# Altium schematic sheet PFM_microcontroller.SchDoc(PFM_microcontroller)
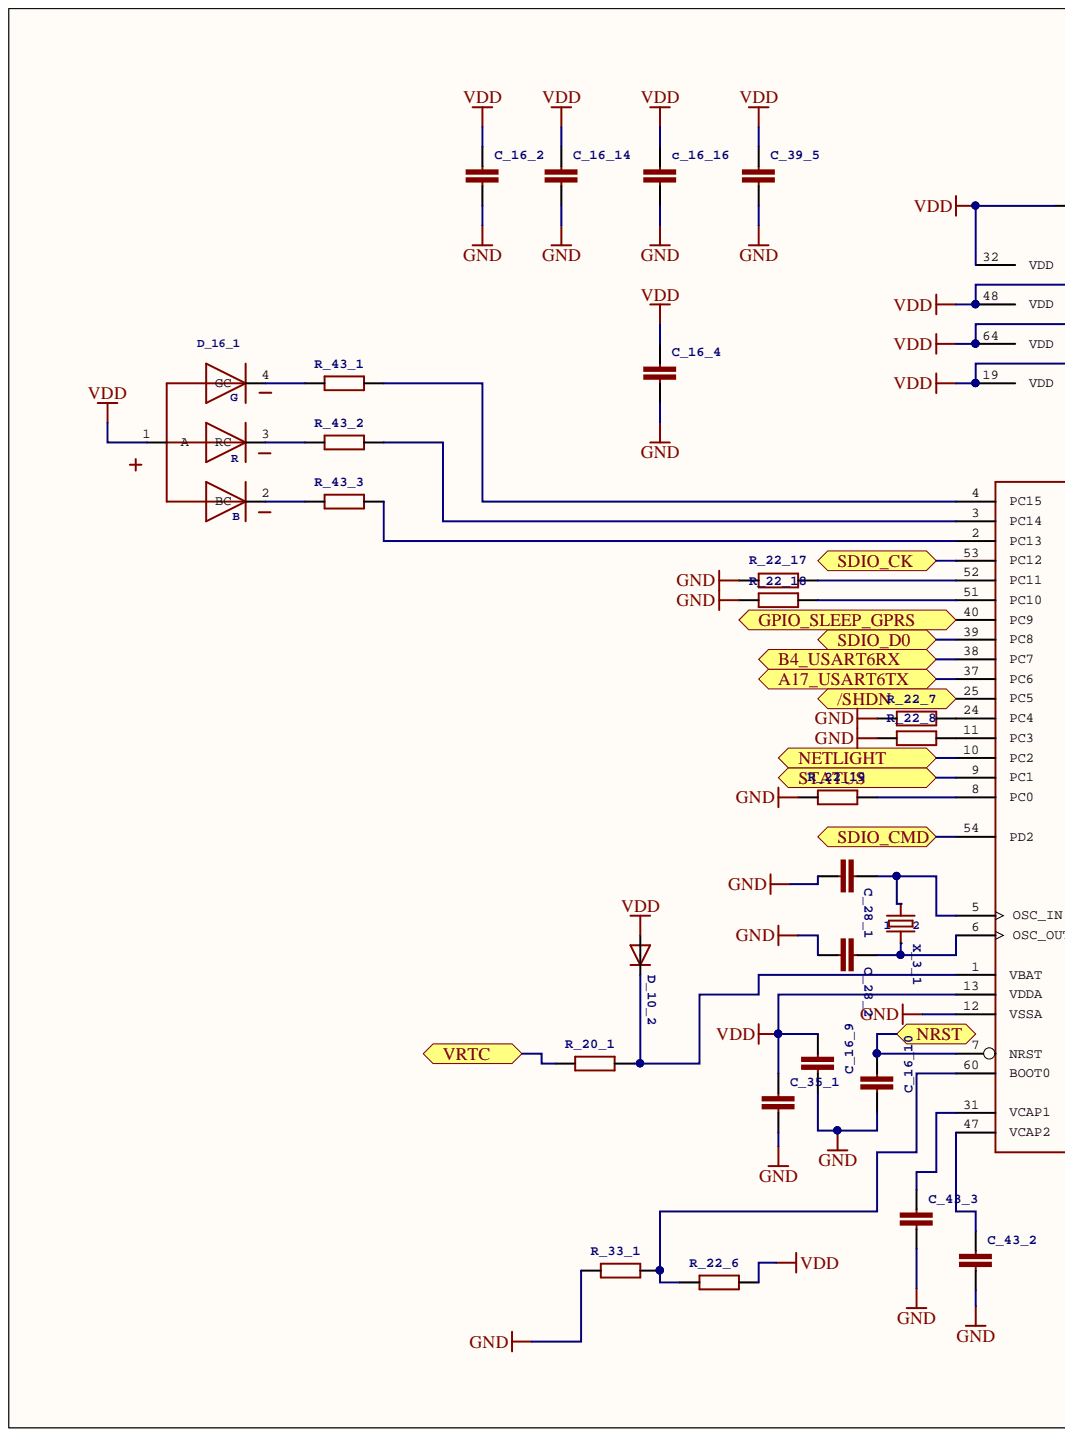
[diagram p0: PFM_microcontroller.SchDoc, left]
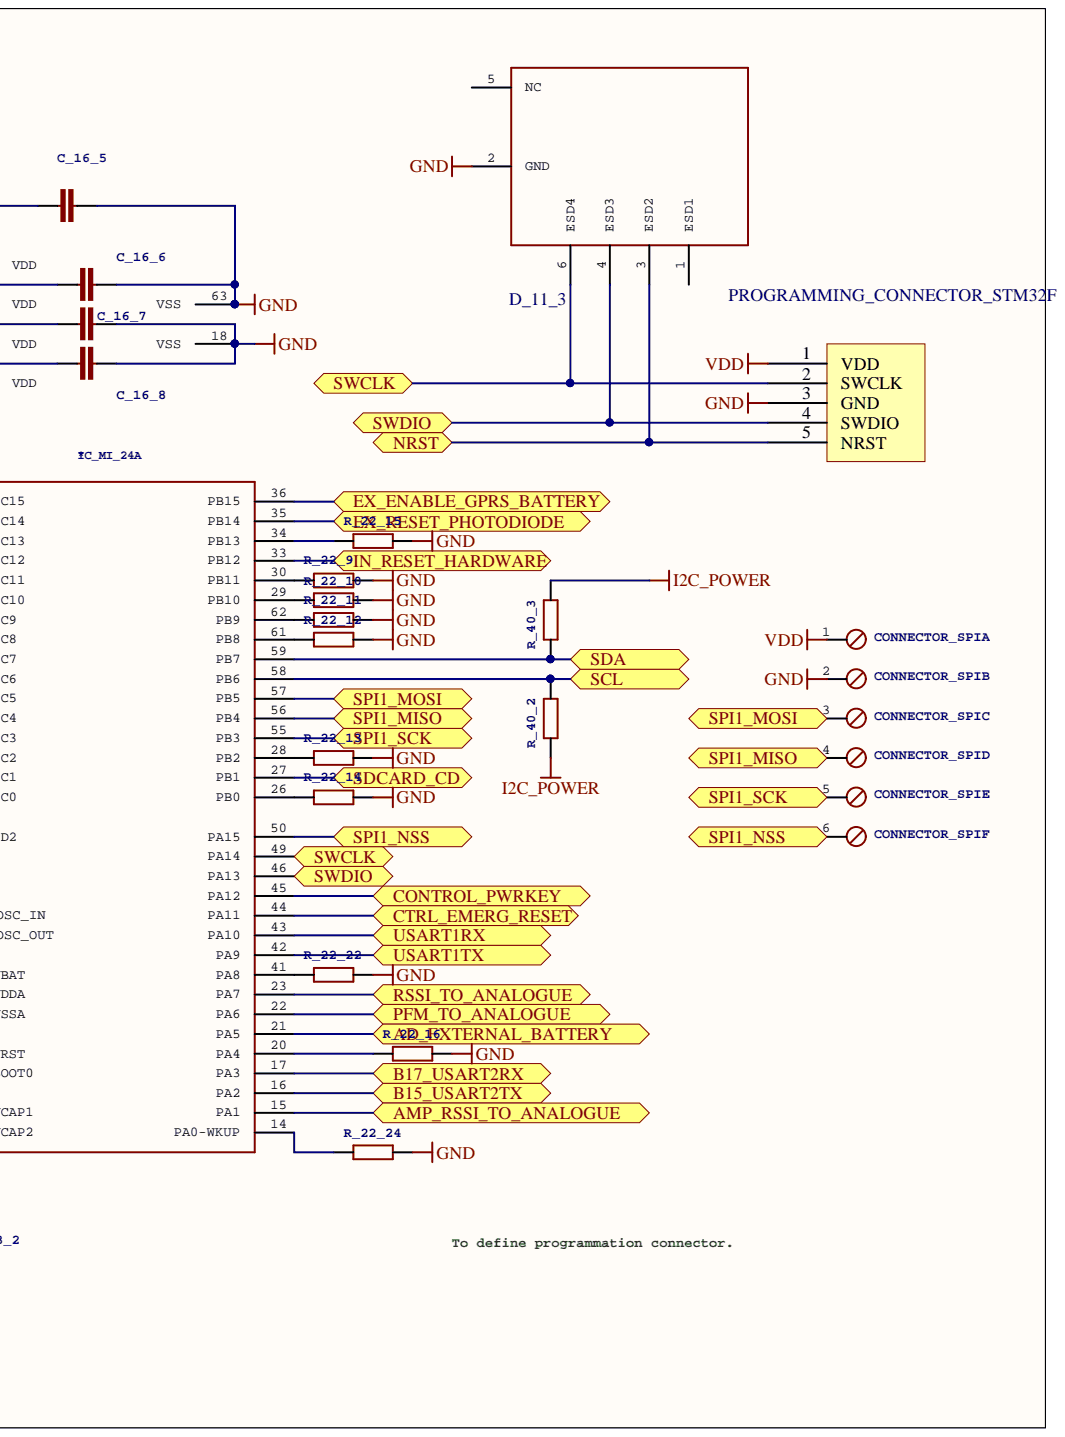
[diagram p1: PFM_microcontroller.SchDoc, right]

## Parts
C_16_2  100nF;CL10B104KB8NNNC;Samsung;  [C0603]  (value not drawn)
C_16_4  100nF;CL10B104KB8NNNC;Samsung;  [C0603]  (value not drawn)
C_16_5  100nF;CL10B104KB8NNNC;Samsung;  [C0603]  (value not drawn)
C_16_6  100nF;CL10B104KB8NNNC;Samsung;  [C0603]  (value not drawn)
C_16_7  100nF;CL10B104KB8NNNC;Samsung;  [C0603]  (value not drawn)
C_16_8  100nF;CL10B104KB8NNNC;Samsung;  [C0603]  (value not drawn)
C_16_9  100nF;CL10B104KB8NNNC;Samsung;  [C0603]  (value not drawn)
C_16_10  100nF;CL10B104KB8NNNC;Samsung;  [C0603]  (value not drawn)
C_16_14  100nF;CL10B104KB8NNNC;Samsung;  [C0603]  (value not drawn)
c_16_16  100nF;CL10B104KB8NNNC;Samsung;  [C0603]  (value not drawn)
C_28_1  10pF;C1608C0G1H100D;TDK  [C0603K]  (value not drawn)
C_28_2  10pF;C1608C0G1H100D;TDK  [C0603K]  (value not drawn)
C_35_1  1uF;MC0603F105Z100CT;Multicomp  [C0603K]  (value not drawn)
C_39_5  4.7uF;CL10A475KO8NNNC;Samsung  [C0603]  (value not drawn)
C_43_2  2.2uF;C0603C225K9PACTU;Kemet  [C0603K]  (value not drawn)
C_43_3  2.2uF;C0603C225K9PACTU;Kemet  [C0603K]  (value not drawn)
CONNECTOR_SPI  PHOENIX CONNECTOR  [6POL254]  (value not drawn)  (parts A,B,C,D,E,F)
D_10_2  SCHOTTKY;PMEG3010BER,115;NEXPERIA  [SOD123]  (value not drawn)
D_11_3  PUSB2X4YH;Nexperia;  [PUSB2X4Y_BRD]  (value not drawn)
D_16_1  RGBLED;150141M173100;WURTH ELECTRONICS  [3528-COMMON-ANODE]  (value not drawn)
IC_MI_24  IC_MI_24;STM32F215RET;STMicroelectronics;  [LQFP64]  (value not drawn)  (parts A,B)
PROGRAMMING_CONNECTOR_STM32F  SIL_PC_5  [SIL_PC_5]  (value not drawn)
R_20_1  0;ERJ3GEY0R00V;Panasonic;  [R0603]  (value not drawn)
R_22_6  10k;MCR03ERTJ103;Rohm  [R0603]  (value not drawn)
R_22_7  10k;MCR03ERTJ103;Rohm  [R0603]  (value not drawn)
R_22_8  10k;MCR03ERTJ103;Rohm  [R0603]  (value not drawn)
R_22_9  10k;MCR03ERTJ103;Rohm  [R0603]  (value not drawn)
R_22_10  10k;MCR03ERTJ103;Rohm  [R0603]  (value not drawn)
R_22_11  10k;MCR03ERTJ103;Rohm  [R0603]  (value not drawn)
R_22_12  10k;MCR03ERTJ103;Rohm  [R0603]  (value not drawn)
R_22_13  10k;MCR03ERTJ103;Rohm  [R0603]  (value not drawn)
R_22_14  10k;MCR03ERTJ103;Rohm  [R0603]  (value not drawn)
R_22_15  10k;MCR03ERTJ103;Rohm  [R0603]  (value not drawn)
R_22_16  10k;MCR03ERTJ103;Rohm  [R0603]  (value not drawn)
R_22_17  10k;MCR03ERTJ103;Rohm  [R0603]  (value not drawn)
R_22_18  10k;MCR03ERTJ103;Rohm  [R0603]  (value not drawn)
R_22_19  10k;MCR03ERTJ103;Rohm  [R0603]  (value not drawn)
R_22_22  10k;MCR03ERTJ103;Rohm  [R0603]  (value not drawn)
R_22_24  10k;MCR03ERTJ103;Rohm  [R0603]  (value not drawn)
R_33_1  470;ERJ-3GEYJ471V;Panasonic  [R0603]  (value not drawn)
R_40_2  2k;ERJ-3GEYJ202V;Panasonic  [R0603]  (value not drawn)
R_40_3  2k;ERJ-3GEYJ202V;Panasonic  [R0603]  (value not drawn)
R_43_1  180;RC1608J181CS;Samsung  [R0603]  (value not drawn)
R_43_2  180;RC1608J181CS;Samsung  [R0603]  (value not drawn)
R_43_3  180;RC1608J181CS;Samsung  [R0603]  (value not drawn)
X_3_1  26MHz;7B-26.000MEEQ-T;TXC  [CTS406]  (value not drawn)

## Ports
/SHDN, A17_USART6TX, AD_EXTERNAL_BATTERY, AMP_RSSI_TO_ANALOGUE, B4_USART6RX, B15_USART2TX, B17_USART2RX, CONTROL_PWRKEY, CTRL_EMERG_RESET, EX_ENABLE_GPRS_BATTERY, EX_RESET_PHOTODIODE, GPIO_SLEEP_GPRS, IN_RESET_HARDWARE, NETLIGHT, NRST, PFM_TO_ANALOGUE, RSSI_TO_ANALOGUE, SCL, SDA, SDCARD_CD, SDIO_CK, SDIO_CMD, SDIO_D0, SPI1_MISO, SPI1_MOSI, SPI1_NSS, SPI1_SCK, STATUS, SWCLK, SWDIO, USART1RX, USART1TX, VRTC

## Nets
GND: C_16_2.2 C_16_4.2 C_16_5.2 C_16_6.2 C_16_7.2 C_16_8.2 C_16_9.1 C_16_10.1 C_16_14.2 c_16_16.2 C_28_1.2 C_28_2.2 C_35_1.2 C_39_5.2 C_43_2.2 C_43_3.2 CONNECTOR_SPI.2 D_11_3.2(GND) IC_MI_24.12(VSSA) IC_MI_24.18(VSS) IC_MI_24.63(VSS) PROGRAMMING_CONNECTOR_STM32F.3(GND) R_22_7.1 R_22_8.1 R_22_9.2 R_22_10.2 R_22_11.2 R_22_12.2 R_22_13.2 R_22_14.2 R_22_15.2 R_22_16.2 R_22_17.1 R_22_18.1 R_22_19.1 R_22_22.2 R_22_24.2 R_33_1.1
I2C_POWER: R_40_2.1 R_40_3.2
VDD: C_16_2.1 C_16_4.1 C_16_5.1 C_16_6.1 C_16_7.1 C_16_8.1 C_16_9.2 C_16_14.1 c_16_16.1 C_35_1.1 C_39_5.1 CONNECTOR_SPI.1 D_10_2.A D_16_1.1(A) IC_MI_24.13(VDDA) IC_MI_24.19(VDD) IC_MI_24.32(VDD) IC_MI_24.48(VDD) IC_MI_24.64(VDD) PROGRAMMING_CONNECTOR_STM32F.1(VDD) R_22_6.2
/SHDN: IC_MI_24.25(PC5) ; port /SHDN
A17_USART6TX: IC_MI_24.37(PC6) ; port A17_USART6TX
AD_EXTERNAL_BATTERY: IC_MI_24.21(PA5) ; port AD_EXTERNAL_BATTERY
AMP_RSSI_TO_ANALOGUE: IC_MI_24.15(PA1) ; port AMP_RSSI_TO_ANALOGUE
B4_USART6RX: IC_MI_24.38(PC7) ; port B4_USART6RX
B15_USART2TX: IC_MI_24.16(PA2) ; port B15_USART2TX
B17_USART2RX: IC_MI_24.17(PA3) ; port B17_USART2RX
CONTROL_PWRKEY: IC_MI_24.45(PA12) ; port CONTROL_PWRKEY
CTRL_EMERG_RESET: IC_MI_24.44(PA11) ; port CTRL_EMERG_RESET
EX_ENABLE_GPRS_BATTERY: IC_MI_24.36(PB15) ; port EX_ENABLE_GPRS_BATTERY
EX_RESET_PHOTODIODE: IC_MI_24.35(PB14) ; port EX_RESET_PHOTODIODE
GPIO_SLEEP_GPRS: IC_MI_24.40(PC9) ; port GPIO_SLEEP_GPRS
IN_RESET_HARDWARE: IC_MI_24.33(PB12) ; port IN_RESET_HARDWARE
NETLIGHT: IC_MI_24.10(PC2) ; port NETLIGHT
NRST: C_16_10.2 D_11_3.3(ESD2) IC_MI_24.7(NRST) PROGRAMMING_CONNECTOR_STM32F.5(NRST) ; port NRST
PFM_TO_ANALOGUE: IC_MI_24.22(PA6) ; port PFM_TO_ANALOGUE
RSSI_TO_ANALOGUE: IC_MI_24.23(PA7) ; port RSSI_TO_ANALOGUE
SCL: IC_MI_24.58(PB6) R_40_2.2 ; port SCL
SDA: IC_MI_24.59(PB7) R_40_3.1 ; port SDA
SDCARD_CD: IC_MI_24.27(PB1) ; port SDCARD_CD
SDIO_CK: IC_MI_24.53(PC12) ; port SDIO_CK
SDIO_CMD: IC_MI_24.54(PD2) ; port SDIO_CMD
SDIO_D0: IC_MI_24.39(PC8) ; port SDIO_D0
SPI1_MISO: CONNECTOR_SPI.4 IC_MI_24.56(PB4) ; port SPI1_MISO
SPI1_MOSI: CONNECTOR_SPI.3 IC_MI_24.57(PB5) ; port SPI1_MOSI
SPI1_NSS: CONNECTOR_SPI.6 IC_MI_24.50(PA15) ; port SPI1_NSS
SPI1_SCK: CONNECTOR_SPI.5 IC_MI_24.55(PB3) ; port SPI1_SCK
STATUS: IC_MI_24.9(PC1) ; port STATUS
SWCLK: D_11_3.6(ESD4) IC_MI_24.49(PA14) PROGRAMMING_CONNECTOR_STM32F.2(SWCLK) ; port SWCLK
SWDIO: D_11_3.4(ESD3) IC_MI_24.46(PA13) PROGRAMMING_CONNECTOR_STM32F.4(SWDIO) ; port SWDIO
USART1RX: IC_MI_24.43(PA10) ; port USART1RX
USART1TX: IC_MI_24.42(PA9) ; port USART1TX
VRTC: R_20_1.1 ; port VRTC
NetC_28_1_1: C_28_1.1 IC_MI_24.5(OSC_IN) X_3_1.1
NetC_28_2_1: C_28_2.1 IC_MI_24.6(OSC_OUT) X_3_1.3
NetC_43_2_1: C_43_2.1 IC_MI_24.47(VCAP2)
NetC_43_3_1: C_43_3.1 IC_MI_24.31(VCAP1)
NetD_10_2_C: D_10_2.C IC_MI_24.1(VBAT) R_20_1.2
NetD_16_1_2: D_16_1.2(BC) R_43_3.1
NetD_16_1_3: D_16_1.3(RC) R_43_2.1
NetD_16_1_4: D_16_1.4(GC) R_43_1.1
NetIC_MI_24_2: IC_MI_24.2(PC13) R_43_3.2
NetIC_MI_24_3: IC_MI_24.3(PC14) R_43_2.2
NetIC_MI_24_4: IC_MI_24.4(PC15) R_43_1.2
NetIC_MI_24_8: IC_MI_24.8(PC0) R_22_19.2
NetIC_MI_24_11: IC_MI_24.11(PC3) R_22_8.2
NetIC_MI_24_14: IC_MI_24.14(PA0-WKUP) R_22_24.1
NetIC_MI_24_20: IC_MI_24.20(PA4) R_22_16.1
NetIC_MI_24_24: IC_MI_24.24(PC4) R_22_7.2
NetIC_MI_24_26: IC_MI_24.26(PB0) R_22_14.1
NetIC_MI_24_28: IC_MI_24.28(PB2) R_22_13.1
NetIC_MI_24_29: IC_MI_24.29(PB10) R_22_10.1
NetIC_MI_24_30: IC_MI_24.30(PB11) R_22_9.1
NetIC_MI_24_34: IC_MI_24.34(PB13) R_22_15.1
NetIC_MI_24_41: IC_MI_24.41(PA8) R_22_22.1
NetIC_MI_24_51: IC_MI_24.51(PC10) R_22_18.2
NetIC_MI_24_52: IC_MI_24.52(PC11) R_22_17.2
NetIC_MI_24_60: IC_MI_24.60(BOOT0) R_22_6.1 R_33_1.2
NetIC_MI_24_61: IC_MI_24.61(PB8) R_22_12.1
NetIC_MI_24_62: IC_MI_24.62(PB9) R_22_11.1

Not connected: D_11_3 1(ESD1) 5(NC)

## Library data of the parts
C_16_2 C_16_4-C_16_10 C_16_14 c_16_16 C_39_5 = C-EUC0603: Library Name: PBv4p0.SCHLIB; Component Kind: Standard
C_28_1 C_28_2 C_35_1 C_43_2 C_43_3 = C-EUC0603K: Library Name: PBv4p0.SCHLIB; Component Kind: Standard
CONNECTOR_SPI = MPT6: OC_NEWARK: unknown; OC_FARNELL: unknown; Component Kind: Standard; Library Name: Easynet_Commboard_v1p1.IntLib
D_10_2 = DIODE-SOD123: DIODE; Library Name: diode.IntLib; Component Kind: Standard
D_11_3 = PUSB2X4Y_ENL: Library Name: ESD.IntLib; Component Kind: Standard
D_16_1 = WL-SFTW-150141M173100-R&G&B: SMD full-color TOP LED     Characteristics    Low energy consumption   High reliability   Low current requirement   Fast switching   No UV/IR radiation   High brightness   RGB individual control   Compact package outline   Black surface     Applications    Flash light   Switch indicators   Equipments indicators   Accent and decorative lighting   Electronic signage   Video displays   Backlight for LCDs, PDAs and TVs   Oven, microwave   Street lighting   Ideal for lateral coupling in light guides        Details see: http://katalog.we-online.de/en/led/WL-SFTW?m   Updated   2015-04-10 2015 (C) Wurth Elektronik; R: R; Library Name: Wurth_Elektronik_Optoelectronics_rev15c.IntLib; G: G; Component Kind: Standard; B: B
IC_MI_24 = STM32F215R*T: ARM 32-bit Cortexâ„¢-M3 CPU   120 MHz maximum frequency 512 to 1024 Kbytes of Flash memory 128 kbytes of SRAM 51 GPIOs 1.8 to 3.6 V application supply; Library Name: micro-stm_v3.IntLib; Component Kind: Standard
R_20_1 R_22_6-R_22_19 R_22_22 R_22_24 R_33_1 R_40_2 R_40_3 R_43_1-R_43_3 = R-EU_R0603: RESISTOR, European symbol; Library Name: PBv4p0.SCHLIB; Component Kind: Standard
X_3_1 = CRYSTALCTS406: CRYSTAL; OC_NEWARK: unknown; OC_FARNELL: unknown; Library Name: crystal.IntLib; Component Kind: Standard; 2: 2; 1: 1
PROGRAMMING_CONNECTOR_STM32F = SIL_PC_5: Component Kind: Standard; Library Name: RFI_CB_v5p0.SCHLIB
